# Altium schematic sheet OSD.SchDoc
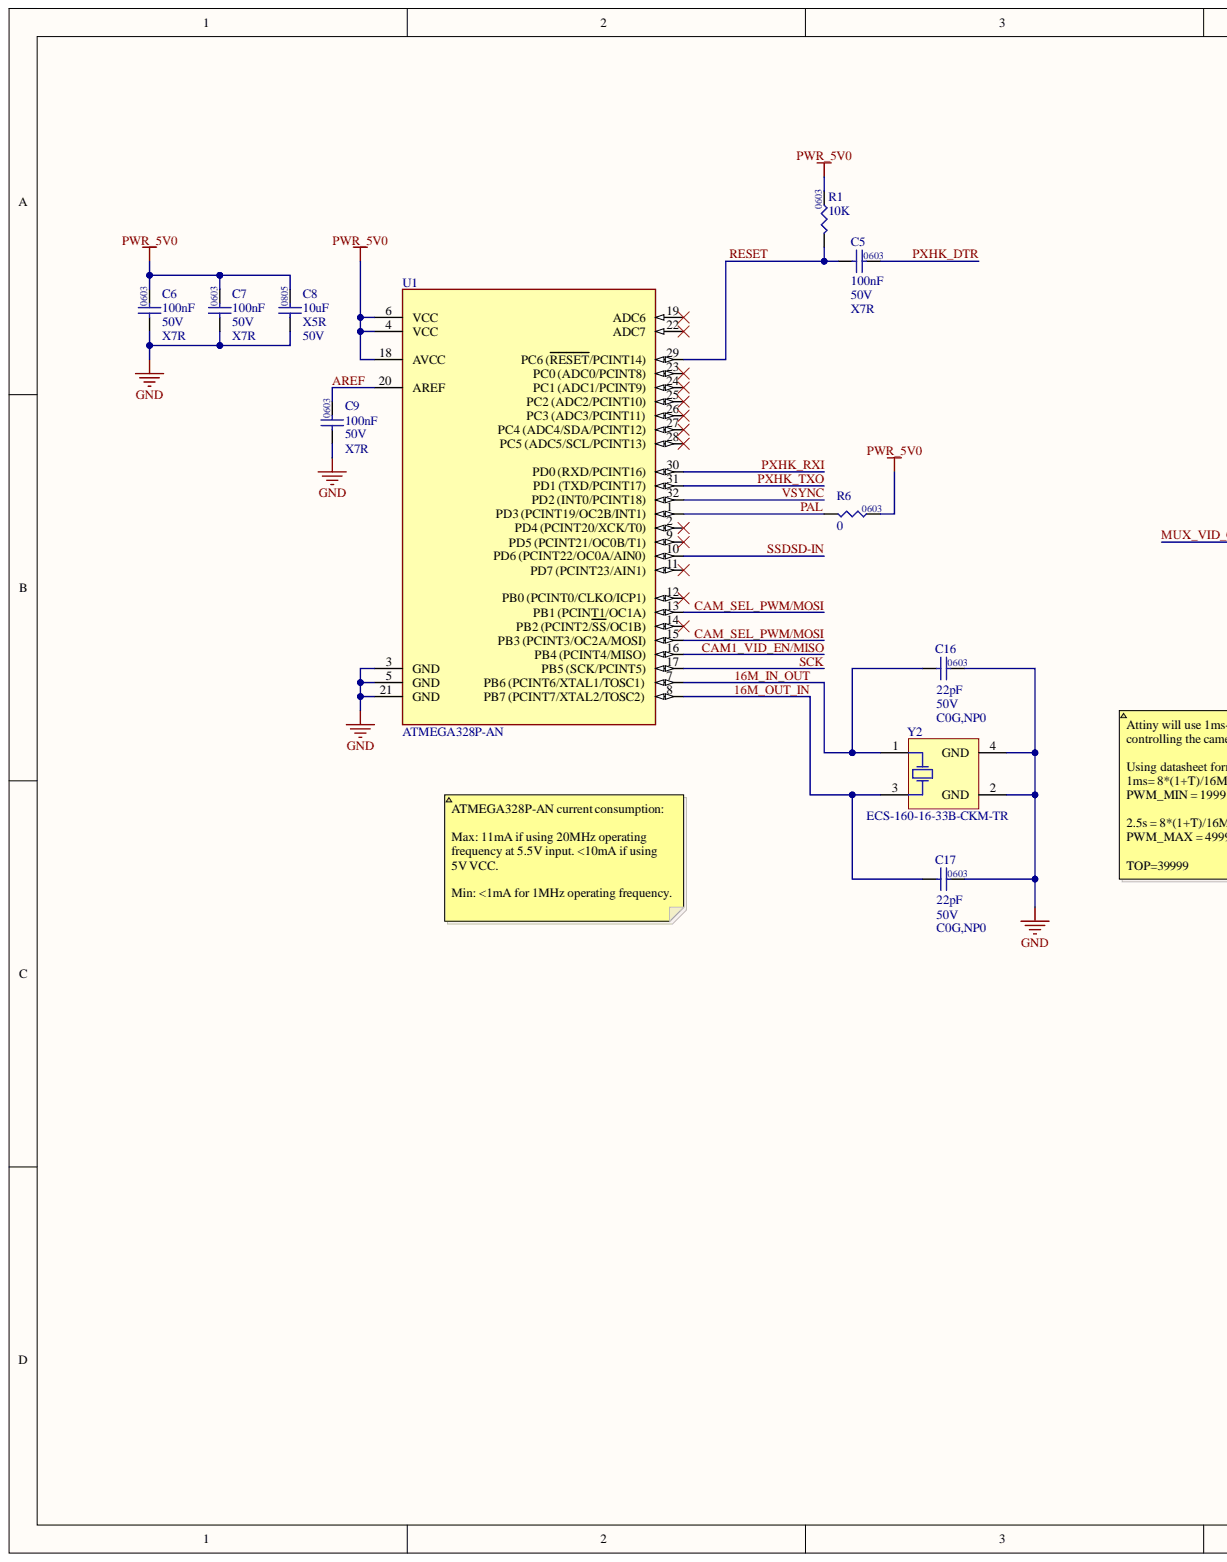
[diagram p0: OSD.SchDoc, left]
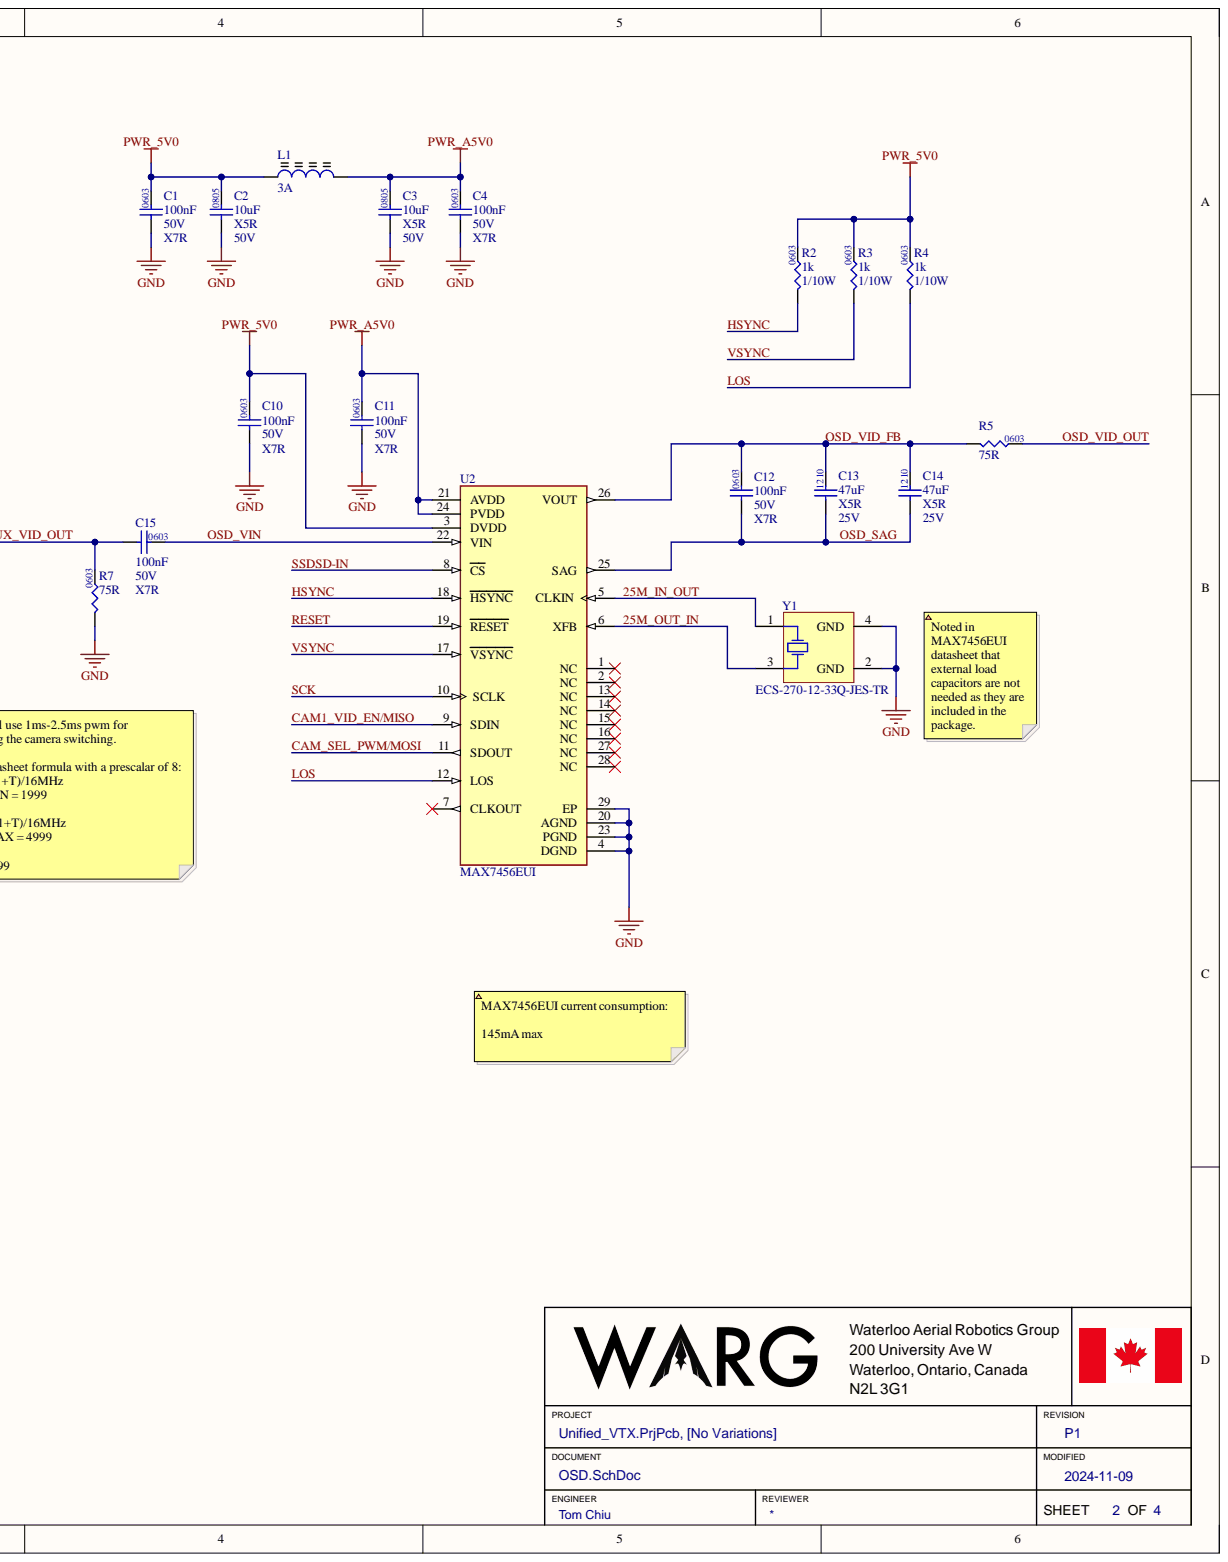
[diagram p1: OSD.SchDoc, right]

## Parts
C1  value 100nF  Temperature Coefficient: X7R  Voltage Rating: 50V  [.CAP_0603-Footprint-1]  (hidden comment: CGA3E2X7R1H104K080AA)
C2  value 10uF  Temperature Coefficient: X5R  Voltage Rating: 50V  [.CAP_0805-Footprint-1]  (hidden comment: GRM21BR61H106KE43L)
C3  value 10uF  Temperature Coefficient: X5R  Voltage Rating: 50V  [.CAP_0805-Footprint-1]  (hidden comment: GRM21BR61H106KE43L)
C4  value 100nF  Temperature Coefficient: X7R  Voltage Rating: 50V  [.CAP_0603-Footprint-1]  (hidden comment: CGA3E2X7R1H104K080AA)
C5  value 100nF  Temperature Coefficient: X7R  Voltage Rating: 50V  [.CAP_0603-Footprint-1]  (hidden comment: CGA3E2X7R1H104K080AA)
C6  value 100nF  Temperature Coefficient: X7R  Voltage Rating: 50V  [.CAP_0603-Footprint-1]  (hidden comment: CGA3E2X7R1H104K080AA)
C7  value 100nF  Temperature Coefficient: X7R  Voltage Rating: 50V  [.CAP_0603-Footprint-1]  (hidden comment: CGA3E2X7R1H104K080AA)
C8  value 10uF  Temperature Coefficient: X5R  Voltage Rating: 50V  [.CAP_0805-Footprint-1]  (hidden comment: GRM21BR61H106KE43L)
C9  value 100nF  Temperature Coefficient: X7R  Voltage Rating: 50V  [.CAP_0603-Footprint-1]  (hidden comment: CGA3E2X7R1H104K080AA)
C10  value 100nF  Temperature Coefficient: X7R  Voltage Rating: 50V  [.CAP_0603-Footprint-1]  (hidden comment: CGA3E2X7R1H104K080AA)
C11  value 100nF  Temperature Coefficient: X7R  Voltage Rating: 50V  [.CAP_0603-Footprint-1]  (hidden comment: CGA3E2X7R1H104K080AA)
C12  value 100nF  Temperature Coefficient: X7R  Voltage Rating: 50V  [.CAP_0603-Footprint-1]  (hidden comment: CGA3E2X7R1H104K080AA)
C13  value 47uF  Case/Package: 1210  Temperature Coefficient: X5R  Voltage Rating: 25V  [.CAP_1210-Footprint-1]  (hidden comment: TMK325ABJ476MM-P)
C14  value 47uF  Case/Package: 1210  Temperature Coefficient: X5R  Voltage Rating: 25V  [.CAP_1210-Footprint-1]  (hidden comment: TMK325ABJ476MM-P)
C15  value 100nF  Temperature Coefficient: X7R  Voltage Rating: 50V  [.CAP_0603-Footprint-1]  (hidden comment: CGA3E2X7R1H104K080AA)
C16  value 22pF  Package: 0603  Voltage: 50V  [.CAP_0603-Footprint-1]  (hidden comment: CC0603JRNPO9BN220)
C17  value 22pF  Package: 0603  Temperature Coefficient: C0G,NP0  Voltage: 50V  [.CAP_0603-Footprint-1]  (hidden comment: CC0603JRNPO9BN220)
L1  Current Rating: 3A  [BLM18SG121TZ1D]  (hidden comment: BLM18SG121TZ1D)
R1  value 10K  [.RES_0603-Footprint-1]  (hidden comment: RC0603JR-1010KL)
R2  value 1k  Package: 0603  Power: 1/10W  [.RES_0603-Footprint-1]  (hidden comment: RC0603FR-071KL)
R3  value 1k  Package: 0603  Power: 1/10W  [.RES_0603-Footprint-1]  (hidden comment: RC0603FR-071KL)
R4  value 1k  Package: 0603  Power: 1/10W  [.RES_0603-Footprint-1]  (hidden comment: RC0603FR-071KL)
R5  Package: 0603  Resistance: 75R  [.RES_0603-Footprint-1]  (hidden comment: RC0603FR-0775RL)
R6  value 0  [.RES_0603-Footprint-1]  (hidden comment: RC0603FR-070RL)
R7  Package: 0603  Resistance: 75R  [.RES_0603-Footprint-1]  (hidden comment: RC0603FR-0775RL)
U1  ATMEGA328P-AN  [ATMEGA328P-AN]
U2  MAX7456EUI  [MAX7456EUI]
Y1  ECS-270-12-33Q-JES-TR  [ECS-270-12-33Q-JES-TR]
Y2  ECS-160-16-33B-CKM-TR  [ECS-160-16-33B-CKM-TR]

## Nets
GND: C1.1 C2.1 C3.1 C4.1 C6.1 C7.1 C8.1 C9.1 C10.1 C11.1 C16.2 C17.2 R7.1 U1.3(GND) U1.5(GND) U1.21(GND) U2.4(DGND) U2.20(AGND) U2.23(PGND) U2.29(EP) Y1.2(GND) Y1.4(GND) Y2.2(GND) Y2.4(GND)
PWR_5V0: C1.2 C2.2 C6.2 C7.2 C8.2 C10.2 L1.1 R1.2 R2.2 R3.2 R4.2 R6.2 U1.4(VCC) U1.6(VCC) U1.18(AVCC) U2.3(DVDD)
PWR_A5V0: C3.2 C4.2 C11.2 L1.2 U2.21(AVDD) U2.24(PVDD)
16M_IN_OUT: C16.1 U1.7 Y2.1
16M_OUT_IN: C17.1 U1.8 Y2.3
25M_IN_OUT: U2.5(CLKIN) Y1.1
25M_OUT_IN: U2.6(XFB) Y1.3
AREF: C9.2 U1.20(AREF)
CAM1_VID_EN/MISO: U1.16(PB4_(PCINT4/MISO)) U2.9(SDIN)
CAM_SEL_PWM/MOSI: U1.13(PB1_(PCINT1/OC1A)) U1.15(PB3_(PCINT3/OC2A/MOSI)) U2.11(SDOUT)
HSYNC: R2.1 U2.18(HSYNC)
LOS: R4.1 U2.12(LOS)
MUX_VID_OUT: C15.1 R7.2
OSD_SAG: C12.1 C13.1 C14.1 U2.25(SAG)
OSD_VID_FB: C12.2 C13.2 C14.2 R5.1 U2.26(VOUT)
OSD_VID_OUT: R5.2
OSD_VIN: C15.2 U2.22(VIN)
PAL: R6.1 U1.1(PD3_(PCINT19/OC2B/INT1))
PXHK_DTR: C5.2
PXHK_RXI: U1.30(PD0_(RXD/PCINT16))
PXHK_TXO: U1.31(PD1_(TXD/PCINT17))
RESET: C5.1 R1.1 U1.29(PC6_(RESET/PCINT14)) U2.19(RESET)
SCK: U1.17(PB5_(SCK/PCINT5)) U2.10(SCLK)
SSDSD-IN: U1.10(PD6_(PCINT22/OC0A/AIN0)) U2.8(CS)
VSYNC: R3.1 U1.32(PD2_(INT0/PCINT18)) U2.17(VSYNC)

Not connected: U1 2(PD4_(PCINT20/XCK/T0)) 9(PD5_(PCINT21/OC0B/T1)) 11(PD7_(PCINT23/AIN1)) 12(PB0_(PCINT0/CLKO/ICP1)) 14(PB2_(PCINT2/SS/OC1B)) 19(ADC6) 22(ADC7) 23(PC0_(ADC0/PCINT8)) 24(PC1_(ADC1/PCINT9)) 25(PC2_(ADC2/PCINT10)) 26(PC3_(ADC3/PCINT11)) 27(PC4_(ADC4/SDA/PCINT12)) 28(PC5_(ADC5/SCL/PCINT13)); U2 1(NC) 2(NC) 7(CLKOUT) 13(NC) 14(NC) 15(NC) 16(NC) 27(NC) 28(NC)

## Library data of the parts
C1 C4-C7 C9-C12 C15 = CMP-00227-5: CAP CER 0.1UF 50V X7R 0603; Component Kind: Standard; Library Name: WARG
C2 C3 C8 = CMP-001-00065-3: CAP CER 10UF 50V X5R 0805; Component Kind: Standard; Library Name: WARG
C13 C14 = CMP-00305-1: CAP CER 47UF 25V X5R 1210; Tolerance (fill in as +-): 20%; Component Kind: Standard; Operating Temperature Range: -55°C ~ 85°C; Library Name: WARG
C16 = CMP-00246-3: CAP CER 22PF 50V C0G/NPO 0603; Temperature Coefficient: C0G,NP0; Tolerance: 5%; Component Kind: Standard; Library Name: WARG
C17 = CMP-00246-3: CAP CER 22PF 50V C0G/NPO 0603; Tolerance: 5%; Component Kind: Standard; Library Name: WARG
L1 = CMP-00303-1: FERRITE BEAD 120 OHM 0603 1LN; DC Resistance (DCR): 25mR; Impedance @ 100MHz: 120R; Number of Lines: 1; Tolerance: 25%; Case/Package: 0603; Component Kind: Standard; Inductor Type: FERRITE BEAD; Max Current Rating: 3A; Operating Temperature Range: -55°C ~ 125°C; Library Name: WARG
R1 = CMP-009-00039-1: RES 10K OHM 5% 1/10W 0603; Component Kind: Standard; Library Name: WARG
R2-R4 = CMP-009-00012-4: RES 1k OHM 1% 1/10W 0603; Component Kind: Standard; Tolerance: 1%; Library Name: WARG
R5 R7 = CMP-009-00098-2: RES 75 OHM 1% 1/10W 0603; Power: 100mW; Temperature Coefficient: 100ppm/°C; Component Kind: Standard; Mounting Technology: Surface Mount; Operating Temperature Range: -55°C ~ 155°C; Tolerance: 1%; Library Name: WARG
R6 = CMP-00139-2: RES 0 OHM JUMPER 1/10W 0603; Component Kind: Standard; Library Name: WARG
U1 = CMP-00283-3: IC MCU 8BIT 32KB FLASH 32TQFP; Clock Frequency: 20MHz; Number of I/O Lines: 23; Supply Voltage Range: 4.5V ~ 5.5V; Case/Package: TQFP; Component Kind: Standard; Max Operating Temperature: 105°C; Operating Temperature Range: -40°C ~ 105°C; Pins: 32; Surface Mount: Yes; Library Name: WARG
U2 = CMP-00284-5: IC OSD VIDEO GEN 28TSSOP; Character Array Size: 12 X 18; Package Equivalence Code: TSSOP28,.25; Supply Voltage Range: 4.75V ~ 5.25V; Component Kind: Standard; Operating Temperature Range: -40°C ~ 85°C; Pins: 28; Surface Mount: Yes; Library Name: WARG
Y1 = CMP-00286-5: CRYSTAL 27.0000MHZ 12PF SMD; Equivalent Series Resistance: 40Ω; Frequency: 27MHz; Frequency Stability: 0.005%; Frequency Tolerance: 20ppm; Component Kind: Standard; Load Capacitance: 12pF; Manufacturing Part Number: ECS-270-12-33Q-JES-TR; Mounting Technology: Surface Mount; Operating Temperature Range: -40°C ~ 125°C; Library Name: WARG
Y2 = CMP-00396-3: CRYSTAL 16.0000MHZ 16PF SMD; Manufacturing Part Number: ECS-160-9-33B-CWN-TR; Equivalent Series Resistance: 60Ω; Frequency: 16MHz; Frequency Stability: ±10ppm; Load Capacitance: 12pF; Component Kind: Standard; Frequency Tolerance: ±10ppm; Mounting Technology: Surface Mount; Operating Temperature Range: -20°C ~ 70°C; Library Name: WARG
